# Altium schematic sheet Sheet2.SchDoc
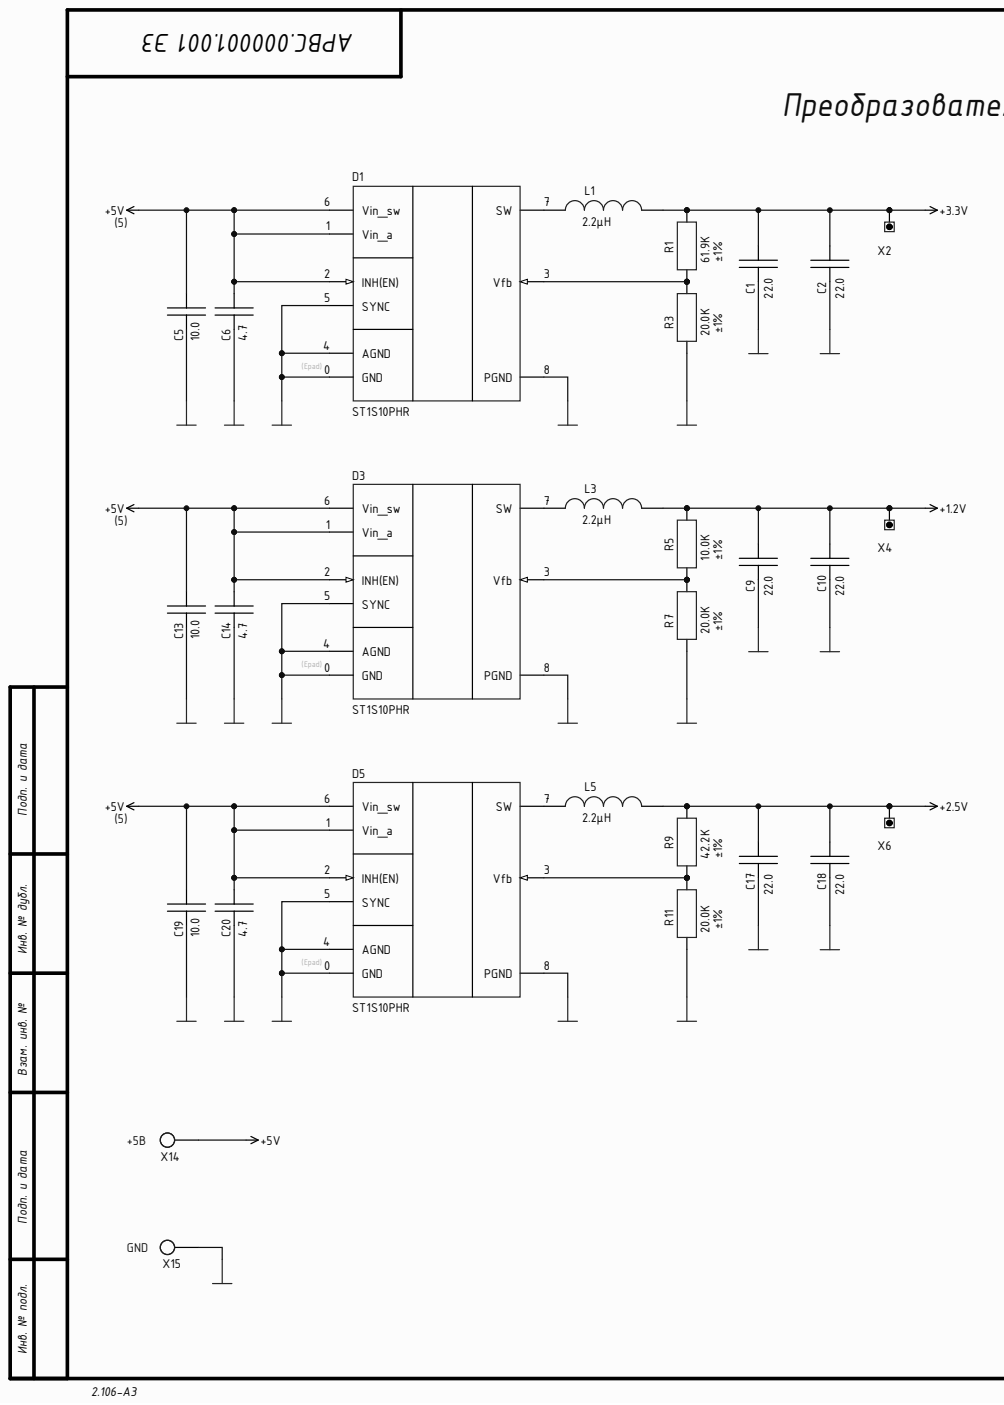
[diagram p0: Sheet2.SchDoc, left]
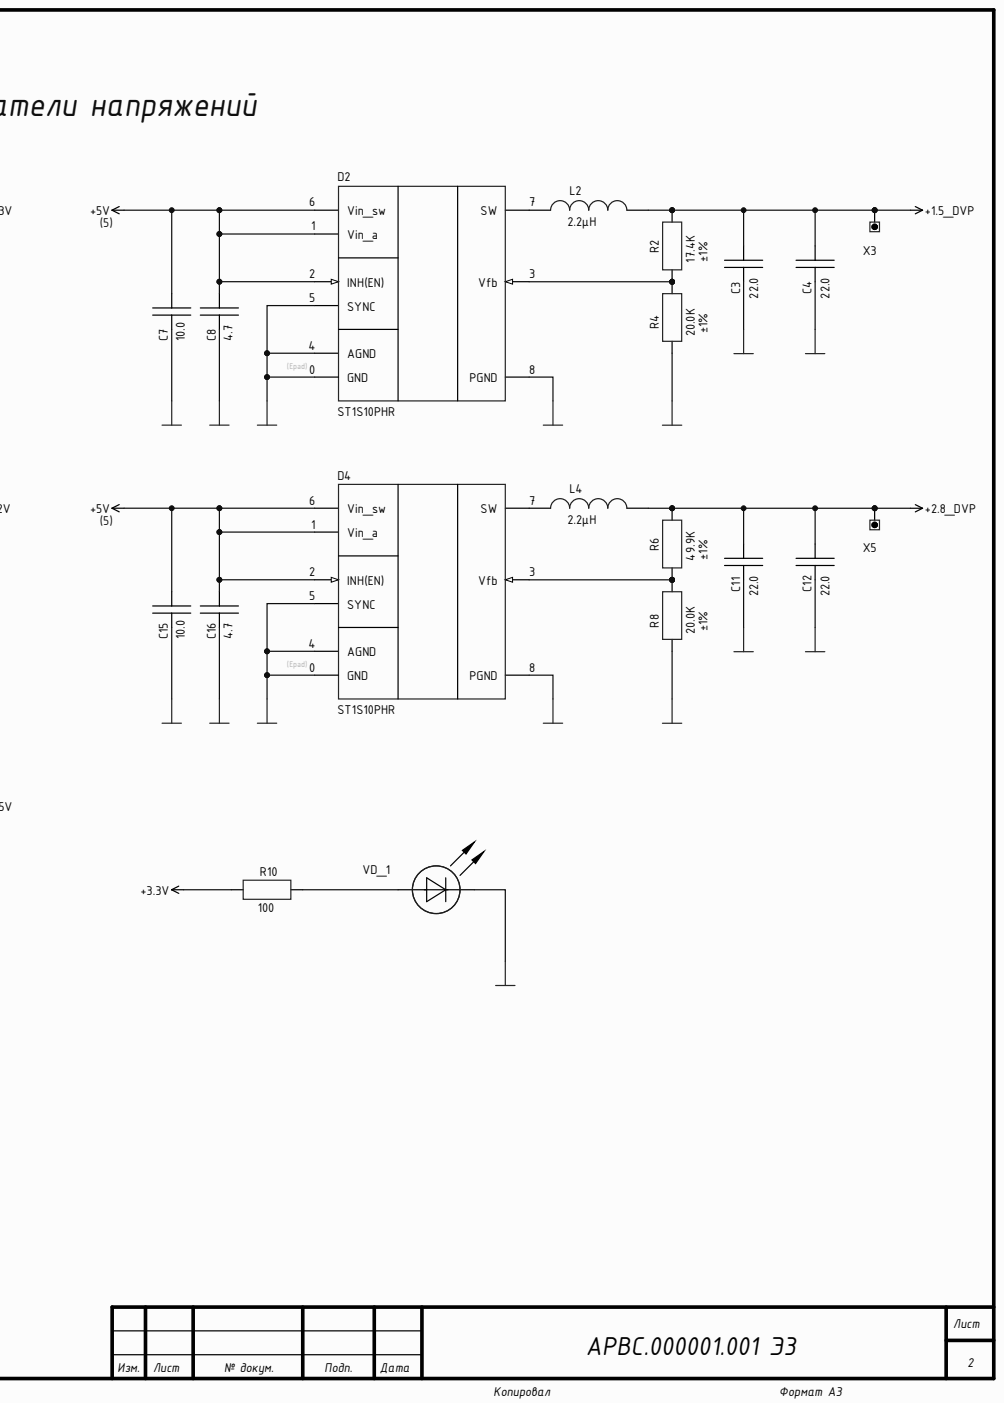
[diagram p1: Sheet2.SchDoc, right]

## Parts
C1  value 22.0  [CAP: 0805]  (hidden comment: Ceramic capacitor, 22.0, X5R, ±20%, 6.3V, 0805, -55...+85°C)
C2  value 22.0  [CAP: 0805]  (hidden comment: Ceramic capacitor, 22.0, X5R, ±20%, 6.3V, 0805, -55...+85°C)
C3  value 22.0  [CAP: 0805]  (hidden comment: Ceramic capacitor, 22.0, X5R, ±20%, 6.3V, 0805, -55...+85°C)
C4  value 22.0  [CAP: 0805]  (hidden comment: Ceramic capacitor, 22.0, X5R, ±20%, 6.3V, 0805, -55...+85°C)
C5  value 10.0  [CAP: 1206]  (hidden comment: Ceramic capacitor, 10.0, X7R, ±10%, 50V, 1206, -55...+125°C)
C6  value 4.7  [CAP: 0805]  (hidden comment: Ceramic capacitor, 4.7, X7R, ±10%, 50V, 0805, -55...+125°C)
C7  value 10.0  [CAP: 1206]  (hidden comment: Ceramic capacitor, 10.0, X7R, ±10%, 50V, 1206, -55...+125°C)
C8  value 4.7  [CAP: 0805]  (hidden comment: Ceramic capacitor, 4.7, X7R, ±10%, 50V, 0805, -55...+125°C)
C9  value 22.0  [CAP: 0805]  (hidden comment: Ceramic capacitor, 22.0, X5R, ±20%, 6.3V, 0805, -55...+85°C)
C10  value 22.0  [CAP: 0805]  (hidden comment: Ceramic capacitor, 22.0, X5R, ±20%, 6.3V, 0805, -55...+85°C)
C11  value 22.0  [CAP: 0805]  (hidden comment: Ceramic capacitor, 22.0, X5R, ±20%, 6.3V, 0805, -55...+85°C)
C12  value 22.0  [CAP: 0805]  (hidden comment: Ceramic capacitor, 22.0, X5R, ±20%, 6.3V, 0805, -55...+85°C)
C13  value 10.0  [CAP: 1206]  (hidden comment: Ceramic capacitor, 10.0, X7R, ±10%, 50V, 1206, -55...+125°C)
C14  value 4.7  [CAP: 0805]  (hidden comment: Ceramic capacitor, 4.7, X7R, ±10%, 50V, 0805, -55...+125°C)
C15  value 10.0  [CAP: 1206]  (hidden comment: Ceramic capacitor, 10.0, X7R, ±10%, 50V, 1206, -55...+125°C)
C16  value 4.7  [CAP: 0805]  (hidden comment: Ceramic capacitor, 4.7, X7R, ±10%, 50V, 0805, -55...+125°C)
C17  value 22.0  [CAP: 0805]  (hidden comment: Ceramic capacitor, 22.0, X5R, ±20%, 6.3V, 0805, -55...+85°C)
C18  value 22.0  [CAP: 0805]  (hidden comment: Ceramic capacitor, 22.0, X5R, ±20%, 6.3V, 0805, -55...+85°C)
C19  value 10.0  [CAP: 1206]  (hidden comment: Ceramic capacitor, 10.0, X7R, ±10%, 50V, 1206, -55...+125°C)
C20  value 4.7  [CAP: 0805]  (hidden comment: Ceramic capacitor, 4.7, X7R, ±10%, 50V, 0805, -55...+125°C)
D1  PartNumber: ST1S10PHR  [8-SOIC-PAD]  (hidden comment: DC/DC buck converter, input 2.5...18V, 900kHz, output 0.8...16.2V, 3A, -40...+125°C, 8-SOIC)
D2  PartNumber: ST1S10PHR  [8-SOIC-PAD]  (hidden comment: DC/DC buck converter, input 2.5...18V, 900kHz, output 0.8...16.2V, 3A, -40...+125°C, 8-SOIC)
D3  PartNumber: ST1S10PHR  [8-SOIC-PAD]  (hidden comment: DC/DC buck converter, input 2.5...18V, 900kHz, output 0.8...16.2V, 3A, -40...+125°C, 8-SOIC)
D4  PartNumber: ST1S10PHR  [8-SOIC-PAD]  (hidden comment: DC/DC buck converter, input 2.5...18V, 900kHz, output 0.8...16.2V, 3A, -40...+125°C, 8-SOIC)
D5  PartNumber: ST1S10PHR  [8-SOIC-PAD]  (hidden comment: DC/DC buck converter, input 2.5...18V, 900kHz, output 0.8...16.2V, 3A, -40...+125°C, 8-SOIC)
L1  value 2.2μH  [IND: 7.3_7.3_4.5]  (hidden comment: Fixed inductor, 2.2μH, ±20%, 7.3x7.3x4.5, 2.8A, -40...+150°C)
L2  value 2.2μH  [IND: 7.3_7.3_4.5]  (hidden comment: Fixed inductor, 2.2μH, ±20%, 7.3x7.3x4.5, 2.8A, -40...+150°C)
L3  value 2.2μH  [IND: 7.3_7.3_4.5]  (hidden comment: Fixed inductor, 2.2μH, ±20%, 7.3x7.3x4.5, 2.8A, -40...+150°C)
L4  value 2.2μH  [IND: 7.3_7.3_4.5]  (hidden comment: Fixed inductor, 2.2μH, ±20%, 7.3x7.3x4.5, 2.8A, -40...+150°C)
L5  value 2.2μH  [IND: 7.3_7.3_4.5]  (hidden comment: Fixed inductor, 2.2μH, ±20%, 7.3x7.3x4.5, 2.8A, -40...+150°C)
R1  value 61.9K  Tolerance: ±1%  [RES: 0603]  (hidden comment: Resistor film, 61.9K, ±1%, 0603, 0.1W, -55...+125°C)
R2  value 17.4K  Tolerance: ±1%  [RES: 0603]  (hidden comment: Resistor film, 17.4K, ±1%, 0603, 0.1W, -55...+125°C)
R3  value 20.0K  Tolerance: ±1%  [RES: 0603]  (hidden comment: Resistor film, 20.0K, ±1%, 0603, 0.1W, -55...+125°C)
R4  value 20.0K  Tolerance: ±1%  [RES: 0603]  (hidden comment: Resistor film, 20.0K, ±1%, 0603, 0.1W, -55...+125°C)
R5  value 10.0K  Tolerance: ±1%  [RES: 0603]  (hidden comment: Resistor film, 10.0K, ±1%, 0603, 0.1W, -55...+125°C)
R6  value 49.9K  Tolerance: ±1%  [RES: 0603]  (hidden comment: Resistor film, 49.9K, ±1%, 0603, 0.1W, -55...+125°C)
R7  value 20.0K  Tolerance: ±1%  [RES: 0603]  (hidden comment: Resistor film, 20.0K, ±1%, 0603, 0.1W, -55...+125°C)
R8  value 20.0K  Tolerance: ±1%  [RES: 0603]  (hidden comment: Resistor film, 20.0K, ±1%, 0603, 0.1W, -55...+125°C)
R9  value 42.2K  Tolerance: ±1%  [RES: 0603]  (hidden comment: Resistor film, 42.2K, ±1%, 0603, 0.1W, -55...+125°C)
R10  value 100  [RES: 0603]  (hidden comment: Resistor film, 100, ±1%, 0603, 0.1W, -55...+125°C)
R11  value 20.0K  Tolerance: ±1%  [RES: 0603]  (hidden comment: Resistor film, 20.0K, ±1%, 0603, 0.1W, -55...+125°C)
VD_1  LED, Green, 20mA, 2.9, 0603, -55...+150°C  [LED: 0603G]  (value not drawn)
X2  Test point, Keystone, 5001  [CON: 5000 (Keystone)]  (value not drawn)
X3  Test point, Keystone, 5001  [CON: 5000 (Keystone)]  (value not drawn)
X4  Test point, Keystone, 5001  [CON: 5000 (Keystone)]  (value not drawn)
X5  Test point, Keystone, 5001  [CON: 5000 (Keystone)]  (value not drawn)
X6  Test point, Keystone, 5001  [CON: 5000 (Keystone)]  (value not drawn)
X14  no  [CON: Hole_1]  (value not drawn)
X15  no  [CON: Hole_1]  (value not drawn)

## Nets
+1.2V: C9.2 C10.2 L3.1 R5.2 X4.1
+1.5_DVP: C3.2 C4.2 L2.1 R2.2 X3.1
+2.5V: C17.2 C18.2 L5.1 R9.2 X6.1
+2.8_DVP: C11.2 C12.2 L4.1 R6.2 X5.1
+3.3V: C1.2 C2.2 L1.1 R1.2 R10.1 X2.1
+5V: C5.2 C6.2 C7.2 C8.2 C13.2 C14.2 C15.2 C16.2 C19.2 C20.2 D1.1(Vin_a) D1.2(INH(EN)) D1.6(Vin_sw) D2.1(Vin_a) D2.2(INH(EN)) D2.6(Vin_sw) D3.1(Vin_a) D3.2(INH(EN)) D3.6(Vin_sw) D4.1(Vin_a) D4.2(INH(EN)) D4.6(Vin_sw) D5.1(Vin_a) D5.2(INH(EN)) D5.6(Vin_sw) X14.1
GND: C1.1 C2.1 C3.1 C4.1 C5.1 C6.1 C7.1 C8.1 C9.1 C10.1 C11.1 C12.1 C13.1 C14.1 C15.1 C16.1 C17.1 C18.1 C19.1 C20.1 D1.4(AGND) D1.5(SYNC) D1.8(PGND) D1.Epad(GND) D2.4(AGND) D2.5(SYNC) D2.8(PGND) D2.Epad(GND) D3.4(AGND) D3.5(SYNC) D3.8(PGND) D3.Epad(GND) D4.4(AGND) D4.5(SYNC) D4.8(PGND) D4.Epad(GND) D5.4(AGND) D5.5(SYNC) D5.8(PGND) D5.Epad(GND) R3.1 R4.1 R7.1 R8.1 R11.1 VD_1.2 X15.1
NetD1_3: D1.3(Vfb) R1.1 R3.2
NetD1_7: D1.7(SW) L1.2
NetD2_3: D2.3(Vfb) R2.1 R4.2
NetD2_7: D2.7(SW) L2.2
NetD3_3: D3.3(Vfb) R5.1 R7.2
NetD3_7: D3.7(SW) L3.2
NetD4_3: D4.3(Vfb) R6.1 R8.2
NetD4_7: D4.7(SW) L4.2
NetD5_3: D5.3(Vfb) R9.1 R11.2
NetD5_7: D5.7(SW) L5.2
NetR10_2: R10.2 VD_1.1

## Library data of the parts
C1-C4 C9-C12 C17 C18 = Ceramic_0805: Manufacturer: Murata; PartNumber: GRM21BR60J226M; Component Kind: Standard; HelpURL: https://www.chipdip.ru/product/grm21br60j226m; Library Name: Capacitor.IntLib; Package: 0805; Temperature: -55...+85°C; Tolerance: ±20%; TypeCapacitor: Ceramic capacitor; TypeDielectric: X5R; ValueManufacturerPartNumber: Ceramic capacitor, 22.0, X5R, ±20%, 6.3V, 0805, -55...+85°C; Voltage: 6.3V
C5 C7 C13 C15 C19 = Ceramic_1206: Manufacturer: TDK; PartNumber: C3216X7R1H106K160AC; Component Kind: Standard; HelpURL: https://ru.mouser.com/ProductDetail/TDK/C3216X7R1H106K160AC?qs=gZXFycFWdAOhQ%2F%252B4feavtA%3D%3D; Library Name: Capacitor.IntLib; Package: 1206; Temperature: -55...+125°C; Tolerance: ±10%; TypeCapacitor: Ceramic capacitor; TypeDielectric: X7R; ValueManufacturerPartNumber: Ceramic capacitor, 10.0, X7R, ±10%, 50V, 1206, -55...+125°C; Voltage: 50V
C6 C8 C14 C16 C20 = Ceramic_0805: Manufacturer: TDK; PartNumber: C2012X7R1H475K125AC; Component Kind: Standard; HelpURL: https://ru.mouser.com/ProductDetail/TDK/C2012X7R1H475K125AC?qs=P1JMDcb91o6brNyz9C%252BI3w%3D%3D; Library Name: Capacitor.IntLib; Package: 0805; Temperature: -55...+125°C; Tolerance: ±10%; TypeCapacitor: Ceramic capacitor; TypeDielectric: X7R; ValueManufacturerPartNumber: Ceramic capacitor, 4.7, X7R, ±10%, 50V, 0805, -55...+125°C; Voltage: 50V
D1-D5 = ST1S10: Buck converter; Manufacturer: STMicroelectronics; Component Kind: Standard; ComponentType: DC/DC buck converter; CurrentOutput: 3A; Frequency: 900kHz; HelpURL: https://www.digikey.com/en/products/detail/stmicroelectronics/ST1S10PHR/1852380; Library Name: IC. Power supply.IntLib; Package: 8-SOIC; Temperature: -40...+125°C; ValueManufacturerPartNumber: DC/DC buck converter, input 2.5...18V, 900kHz, output 0.8...16.2V, 3A, -40...+125°C, 8-SOIC; VoltageInput: input 2.5...18V; VoltageOutput: output 0.8...16.2V
L1-L5 = B82472G6: Manufacturer: TDK; PartNumber: B82472G6222M000; Component Kind: Standard; HelpURL: https://ru.mouser.com/ProductDetail/EPCOS-TDK/B82472G6222M000?qs=%2Fha2pyFadujqhIhisqVMTHHASGkO5coiHiGG9y3YAeT384h3ktYM0g%3D%3D; Library Name: Inductor.IntLib; MaxCurrent: 2.8A; Package: 7.3x7.3x4.5; Temperature: -40...+150°C; Tolerance: ±20%; TypeInductor: Fixed inductor; ValueManufacturerPartNumber: Fixed inductor, 2.2μH, ±20%, 7.3x7.3x4.5, 2.8A, -40...+150°C
R1-R11 = Resistor_0603: Manufacturer: Yageo; PartNumber: RC0603JR-07100RL; Component Kind: Standard; HelpURL: https://www.digikey.com/product-detail/en/yageo/RC0603JR-07100RL/311-100GRCT-ND/729644; Library Name: Resistor.IntLib; Package: 0603; Power: 0.1W; Temperature: -55...+125°C; TypeResistor: Resistor film
  R1: ValueManufacturerPartNumber: Resistor film, 61.9K, ±1%, 0603, 0.1W, -55...+125°C
  R2: ValueManufacturerPartNumber: Resistor film, 17.4K, ±1%, 0603, 0.1W, -55...+125°C
  R3 R4 R7 R8 R11: ValueManufacturerPartNumber: Resistor film, 20.0K, ±1%, 0603, 0.1W, -55...+125°C
  R5: ValueManufacturerPartNumber: Resistor film, 10.0K, ±1%, 0603, 0.1W, -55...+125°C
  R6: ValueManufacturerPartNumber: Resistor film, 49.9K, ±1%, 0603, 0.1W, -55...+125°C
  R9: ValueManufacturerPartNumber: Resistor film, 42.2K, ±1%, 0603, 0.1W, -55...+125°C
  R10: Tolerance: ±1%; ValueManufacturerPartNumber: Resistor film, 100, ±1%, 0603, 0.1W, -55...+125°C
VD_1 = LED_single: PartNumber: TO-1608BC-PG; VoltageDrop: 2.9; Color: GREEN; ColorParameter: Green; Component Kind: Standard; Current: 20mA; HelpURL: https://www.chipdip.ru/product/to-1608bc-pg; Library Name: Diode.IntLib; Package: 0603; Temperature: -55...+150°C; TypeDiode: LED; ValueManufacturerPartNumber: LED, Green, 20mA, 2.9, 0603, -55...+150°C
X2-X6 = Connector_Test_Point: PartNumber: 5001; Component Kind: Standard; HelpURL: https://www.digikey.com/product-detail/en/keystone-electronics/5001/36-5001-ND/255327; Library Name: Connector.IntLib; Manufacturer: Keystone; TypeConnector: Test point; ValueManufacturerPartNumber: Test point, Keystone, 5001
X14 X15 = Hole_2_5: TypeConnector: Hole; Component Kind: Standard; CurrentMax: no; HelpURL: no; Library Name: Connector.IntLib; Manufacturer: no; Multichannel: no; PartNumber: no; Pitch: no; ValueManufacturerPartNumber: no; VoltageMax: no
